# Altium schematic sheet Power&FT232.SchDoc
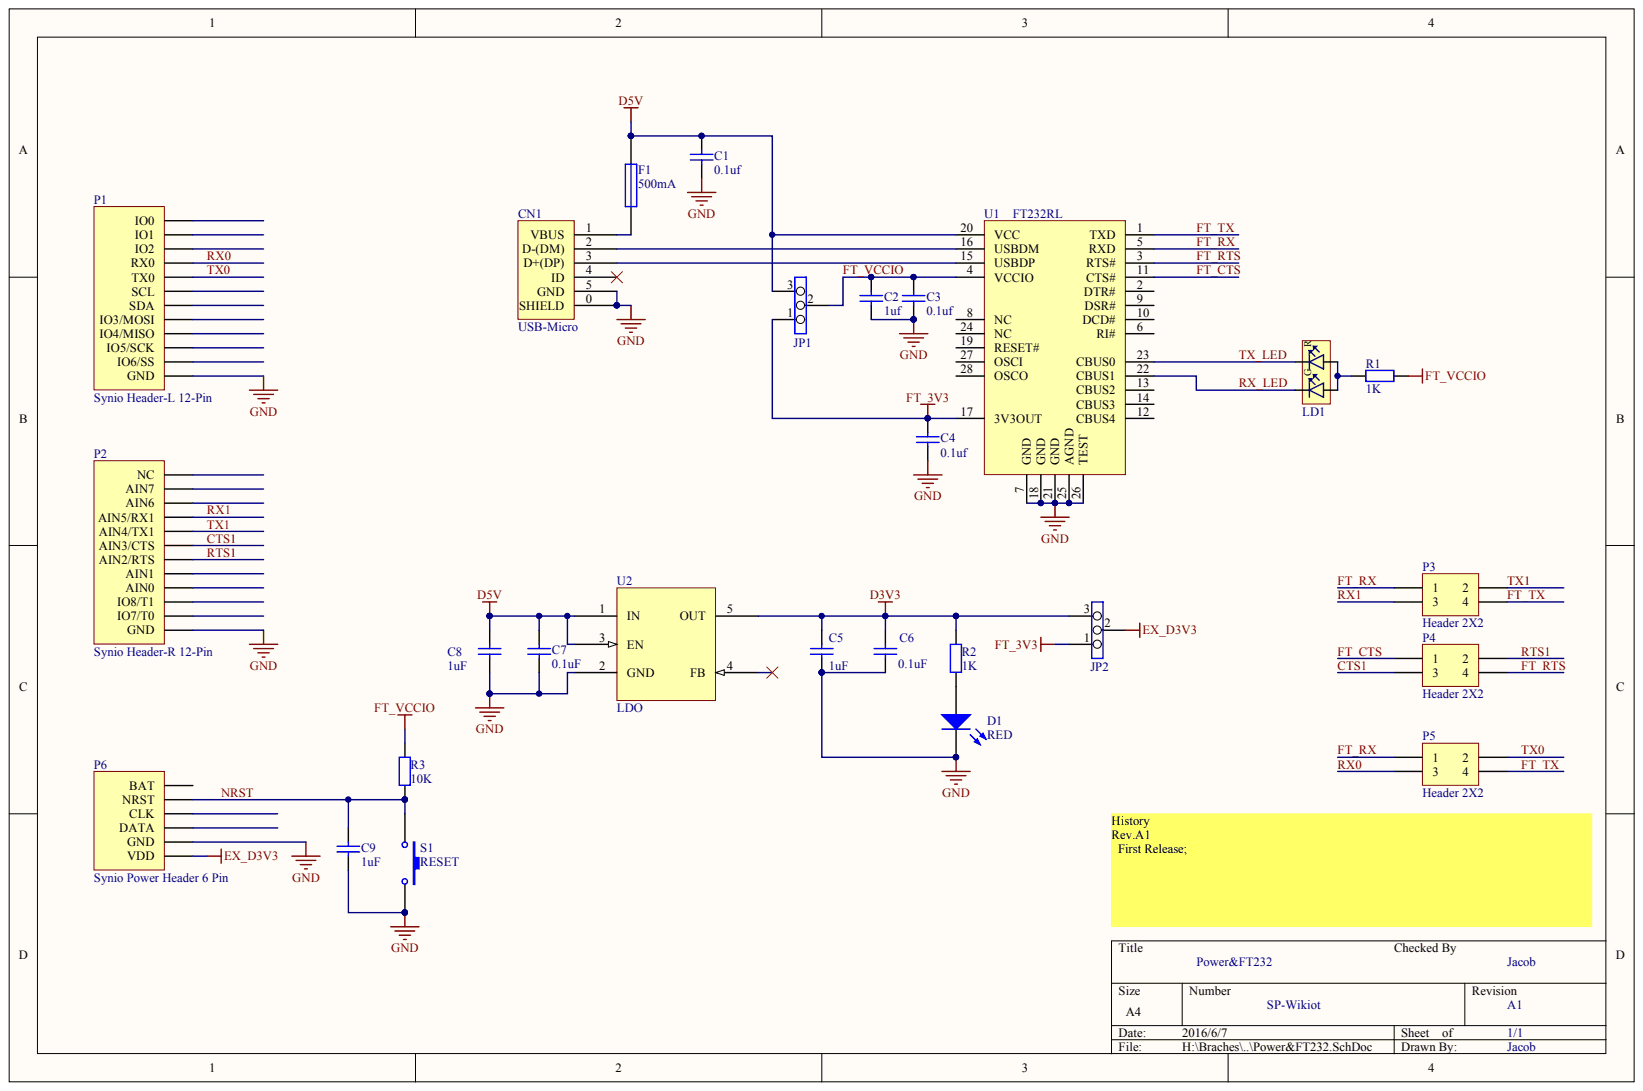
[diagram p0: Power&FT232.SchDoc]

## Parts
C1  value 0.1uf  (hidden comment: Cap)
C2  value 1uf  (hidden comment: Cap)
C3  value 0.1uf  (hidden comment: Cap)
C4  value 0.1uf  (hidden comment: Cap)
C5  1uF
C6  0.1uF
C7  0.1uF
C8  1uF
C9  1uF
CN1  USB-Micro
D1  RED
F1  500mA
JP1  Jumper3  (value not drawn)
JP2  Jumper3  (value not drawn)
LD1  LTST-C195KGJRKT  [LED_DUAL_0606]  (value not drawn)
P1  Synio Header-L 12-Pin
P2  Synio Header-R 12-Pin
P3  Header 2X2
P4  Header 2X2
P5  Header 2X2
P6  Synio Power Header 6 Pin
R1  1K
R2  1K
R3  10K
S1  RESET
U1  FT232RL
U2  LDO

## Nets
D3V3: C5.2 C6.2 JP2.3 R2.2 U2.5(OUT)
D5V: C1.2 C7.2 C8.2 F1.1 JP1.3 U1.20(VCC) U2.1(IN) U2.3(EN)
EX_D3V3: JP2.2 P6.1(VDD)
FT_3V3: C4.2 JP1.1 JP2.1 U1.17(3V3OUT)
GND: C1.1 C2.1 C3.1 C4.1 C5.1 C6.1 C7.1 C8.1 C9.1 CN1.0(SHIELD) CN1.5(GND) D1.2 P1.1(GND) P2.1(GND) P6.2(GND) S1.1 U1.7(GND) U1.18(GND) U1.21(GND) U1.25(AGND) U1.26(TEST) U2.2(GND)
CTS1: P2.7(AIN3/CTS) P4.3
FT_CTS: P4.1 U1.11(CTS#)
FT_RTS: P4.4 U1.3(RTS#)
FT_RX: P3.1 P5.1 U1.5(RXD)
FT_TX: P3.4 P5.4 U1.1(TXD)
FT_VCCIO: C2.2 C3.2 JP1.2 R1.1 R3.1 U1.4(VCCIO)
NRST: C9.2 P6.5(NRST) R3.2 S1.2
RTS1: P2.6(AIN2/RTS) P4.2
RX0: P1.9(RX0) P5.3
RX1: P2.9(AIN5/RX1) P3.3
RX_LED: LD1.1 U1.22(CBUS1)
TX0: P1.8(TX0) P5.2
TX1: P2.8(AIN4/TX1) P3.2
TX_LED: LD1.2 U1.23(CBUS0)
NetCN1_1: CN1.1(VBUS) F1.2
NetCN1_2: CN1.2(D-(DM)) U1.16(USBDM)
NetCN1_3: CN1.3(D+(DP)) U1.15(USBDP)
NetCN1_4: CN1.4(ID)
NetD1_1: D1.1 R2.1
NetLD1_3: LD1.3 LD1.4 R1.2
NetP1_3: P1.3(IO5/SCK)
NetP1_4: P1.4(IO4/MISO)
NetU1_2: U1.2(DTR#)
NetU1_10: U1.10(DCD#)
NetU1_12: U1.12(CBUS4)
NetU1_13: U1.13(CBUS2)
NetU1_14: U1.14(CBUS3)
NetU1_19: U1.19(RESET#)
NetU1_27: U1.27(OSCI)
NetU1_28: U1.28(OSCO)
NetU2_4: U2.4(FB)

Not connected: P1 2(IO6/SS) 5(IO3/MOSI) 6(SDA) 7(SCL) 10(IO2) 11(IO1) 12(IO0); P2 2(IO7/T0) 3(IO8/T1) 4(AIN0) 5(AIN1) 10(AIN6) 11(AIN7) 12(NC); P6 3(DATA) 4(CLK) 6(BAT); U1 6(RI#) 8(NC) 9(DSR#) 24(NC)

## Library data of the parts
LD1 = (no symbol name): Manufacturer: Lite-On Inc; 2nd source part number: 859-LTST-C195KGJRKT; Note: HSMF-A203- xxxxx GaP Red GaP Emerald Green; Artikkelnr Noca: 13019145; Part Number: LTST-C195KGJRKT; Manufacturer Part Number: LTST-C195KGJRKT; Datasheet: http://media.digikey.com/pdf/Data%20Sheets/Lite-On%20PDFs/LTST-C195KGJRKT.pdf; LatestRevisionDate: 2012-07-05; Pin Count: 4; Published: 2012-07-05; Value: LTST-C195KGJRKT; Supplier: Digi-Key; Supplier part number: 160-1452-1-ND; 2nd source Supplier: Mouser; Publisher: Nordic Semiconductor
C1-C9 CN1 D1 F1 JP1 JP2 P1-P6 R1-R3 S1 U1 U2 = (no symbol name)
  C1-C4: Published: 8-Jun-2000; LatestRevisionDate: 17-Jul-2002; LatestRevisionNote: Re-released for DXP Platform.
  C5 C8 C9: Published: 8-Jun-2000; LatestRevisionDate: 17-Jul-2002; LatestRevisionNote: Re-released for DXP Platform.; PackageReference: RAD-0.3; Value: 1uF
  C6 C7: Published: 8-Jun-2000; LatestRevisionDate: 17-Jul-2002; LatestRevisionNote: Re-released for DXP Platform.; PackageReference: RAD-0.3; Value: 0.1uF
  D1: Published: 8-Jun-2000; LatestRevisionDate: 08-Jul-2005; LatestRevisionNote: Mech Layer 1 removed.; PackageReference: LED-0
  F1: Published: 8-Jun-2000; LatestRevisionDate: 17-Jul-2002; LatestRevisionNote: Re-released for DXP Platform.; PackageReference: PIN-W2/E2.8; PackageDescription: Thru-Hole; 2 Leads
  P1-P5: LatestRevisionDate: 17-Jul-2002; LatestRevisionNote: Re-released for DXP Platform.
  R1 R2: Published: 8-Jun-2000; LatestRevisionDate: 17-Jul-2002; LatestRevisionNote: Re-released for DXP Platform.; PackageReference: AXIAL-0.4; Value: 1K
  R3: Published: 8-Jun-2000; LatestRevisionDate: 17-Jul-2002; LatestRevisionNote: Re-released for DXP Platform.; PackageReference: AXIAL-0.4; Value: 10K
  S1: Published: 24-Mar-1999; LatestRevisionDate: 17-Jul-2002; LatestRevisionNote: Re-released for DXP Platform.; PackageReference: SPST-2
  C1-C4 C9 D1 F1 P1-P5 R1-R3 S1: Publisher: Altium Limited
